# Altium schematic sheet H-9220 Backplane Board - DUT Bd1-2 (REV07).SchDoc
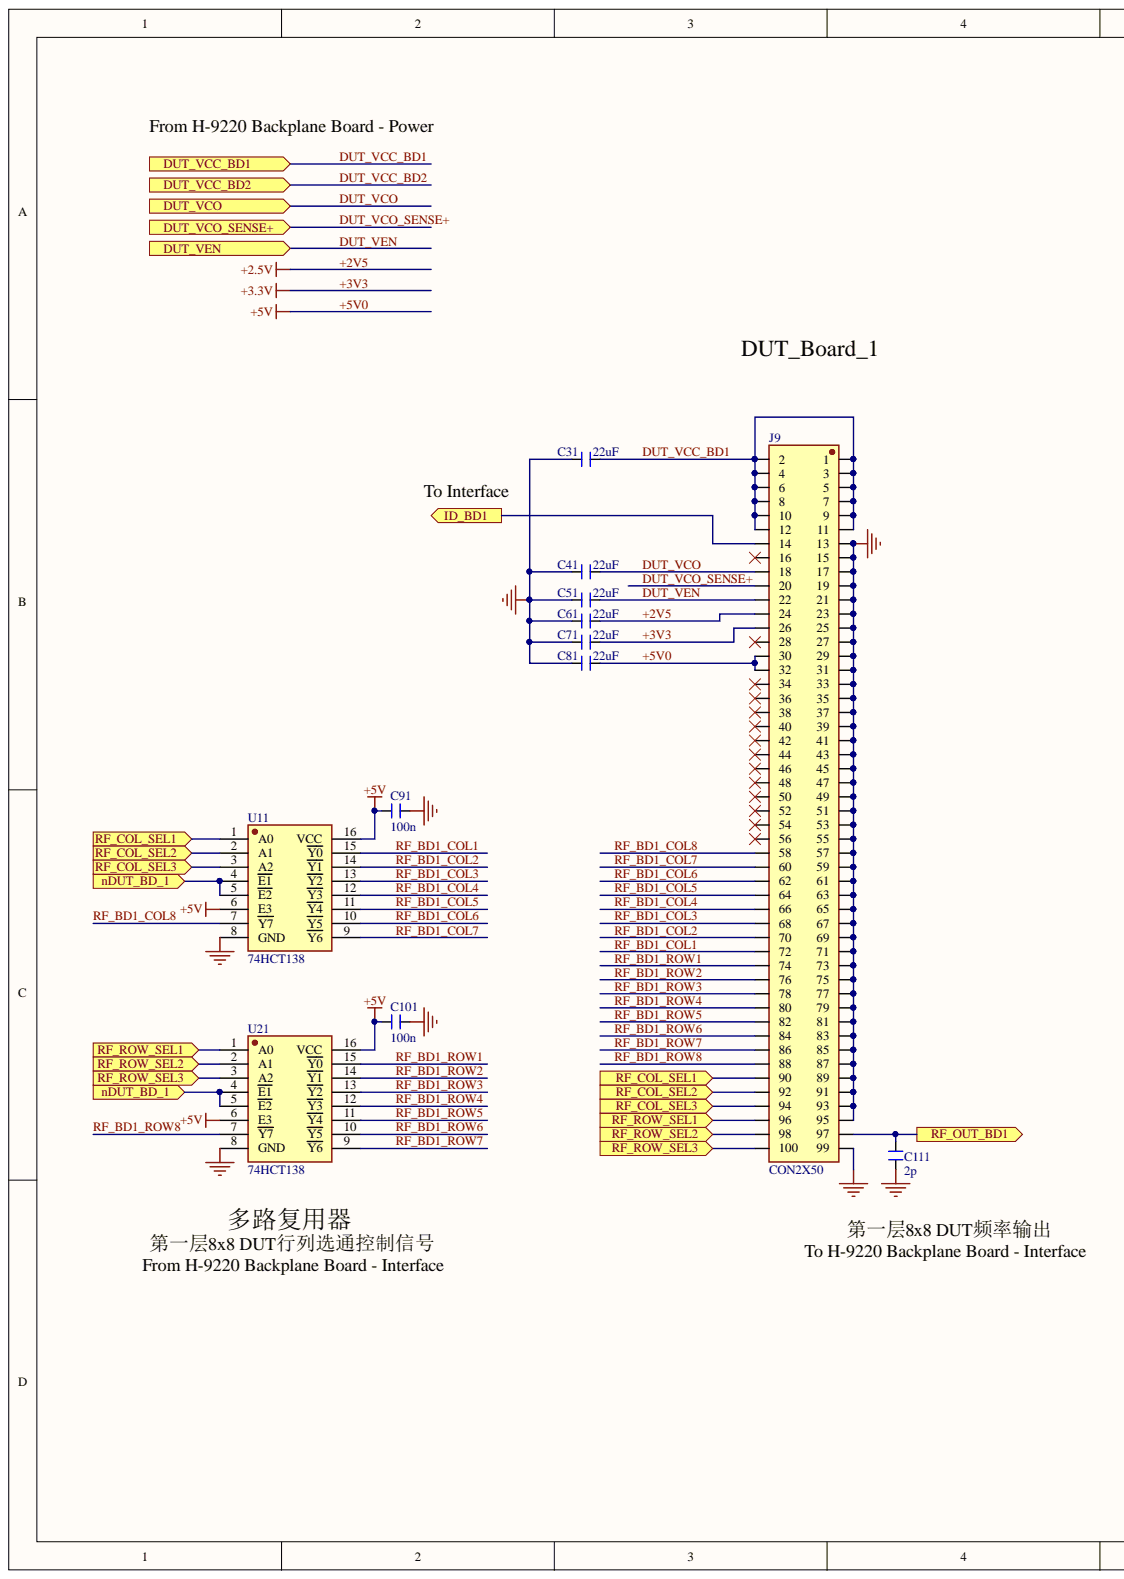
[diagram p0: H-9220 Backplane Board - DUT Bd1-2 (REV07).SchDoc, left]
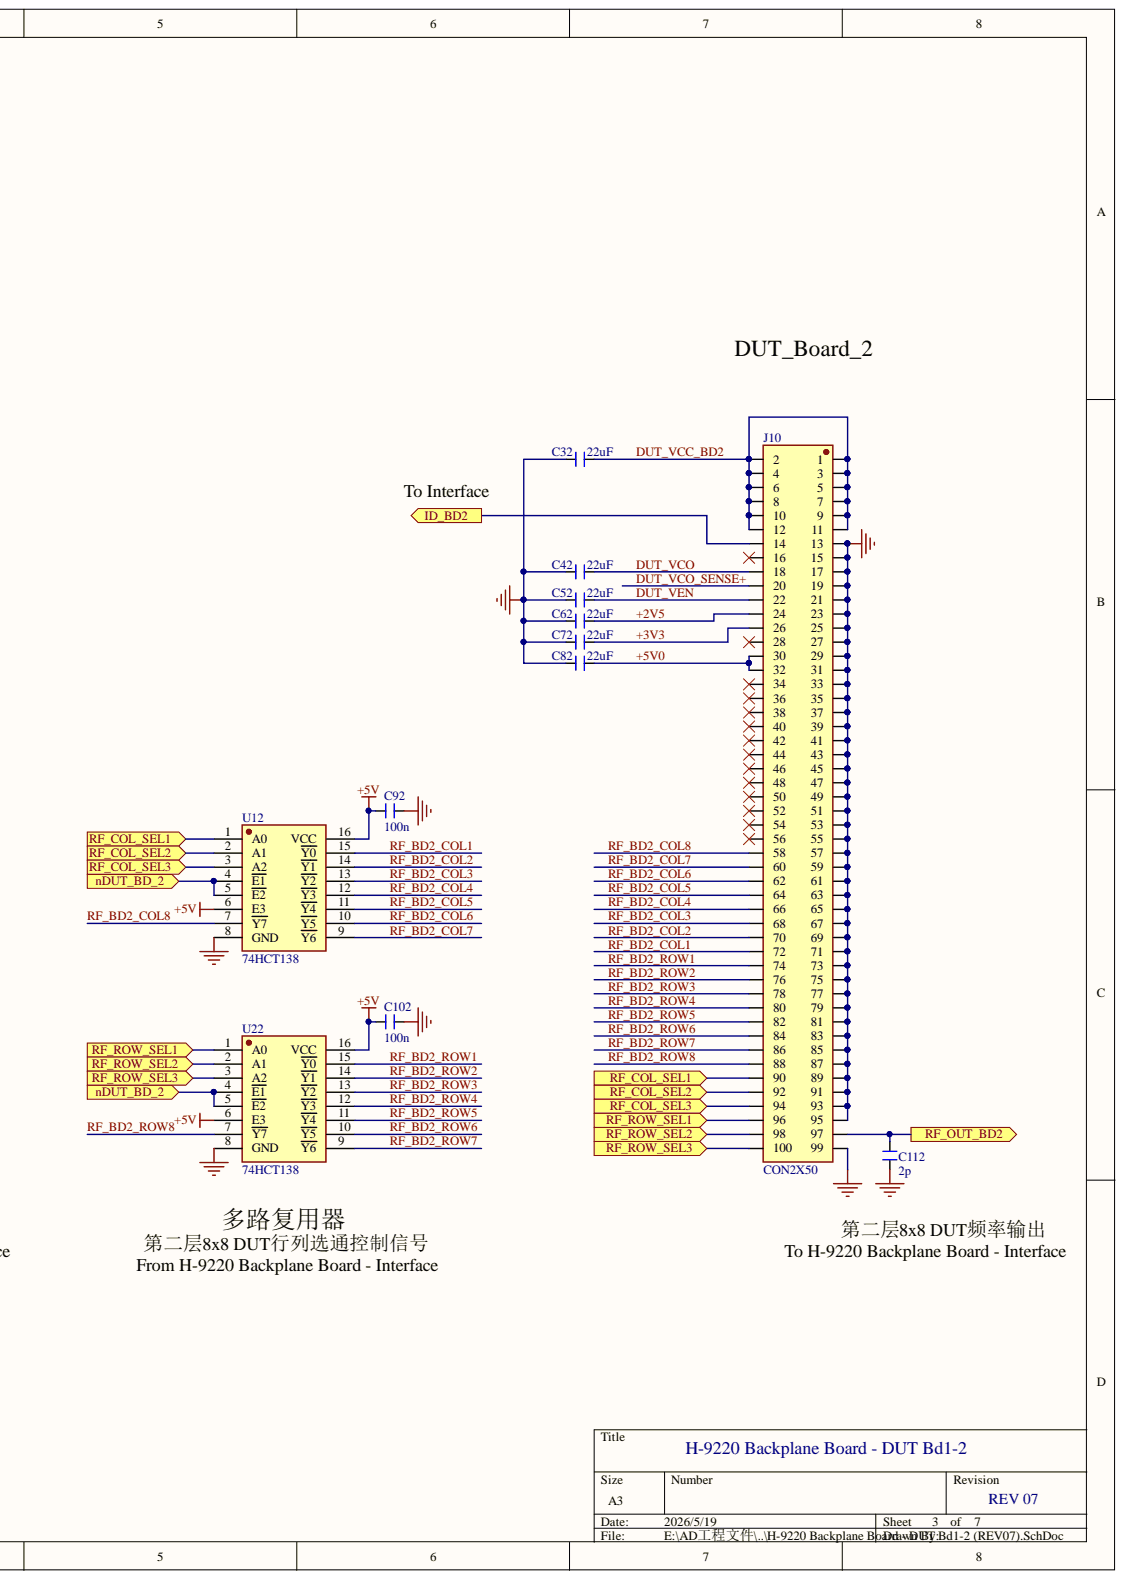
[diagram p1: H-9220 Backplane Board - DUT Bd1-2 (REV07).SchDoc, right]

## Parts
C31  22uF  [C-1206]
C32  22uF  [C-1206]
C41  22uF  [C-1206]
C42  22uF  [C-1206]
C51  22uF  [C-1206]
C52  22uF  [C-1206]
C61  22uF  [C-1206]
C62  22uF  [C-1206]
C71  22uF  [C-1206]
C72  22uF  [C-1206]
C81  22uF  [C-1206]
C82  22uF  [C-1206]
C91  100n  [C-0603]
C92  100n  [C-0603]
C101  100n  [C-0603]
C102  100n  [C-0603]
C111  2p  [C-0603]
C112  2p  [C-0603]
J9  CON2X50  [SFML-150-01-S-D-LC]
J10  CON2X50  [SFML-150-01-S-D-LC]
U11  74HCT138  [SOIC-16 (BODY=3.9MM)]
U12  74HCT138  [SOIC-16 (BODY=3.9MM)]
U21  74HCT138  [SOIC-16 (BODY=3.9MM)]
U22  74HCT138  [SOIC-16 (BODY=3.9MM)]

## Ports
DUT_VCC_BD1, DUT_VCC_BD2, DUT_VCO, DUT_VCO_SENSE+, DUT_VEN, ID_BD1, ID_BD2, nDUT_BD_1, nDUT_BD_2, RF_COL_SEL1, RF_COL_SEL2, RF_COL_SEL3, RF_OUT_BD1, RF_OUT_BD2, RF_ROW_SEL1, RF_ROW_SEL2, RF_ROW_SEL3

## Nets
GND: C31.2 C32.2 C41.2 C42.2 C51.2 C52.2 C61.2 C62.2 C71.2 C72.2 C81.2 C82.2 C91.2 C92.2 C101.2 C102.2 C111.2 C112.2 J9.13 J9.15 J9.17 J9.19 J9.21 J9.23 J9.25 J9.27 J9.29 J9.31 J9.33 J9.35 J9.37 J9.39 J9.41 J9.43 J9.45 J9.47 J9.49 J9.51 J9.53 J9.55 J9.57 J9.59 J9.61 J9.63 J9.65 J9.67 J9.69 J9.71 J9.73 J9.75 J9.77 J9.79 J9.81 J9.83 J9.85 J9.87 J9.89 J9.91 J9.93 J9.95 J9.99 J10.13 J10.15 J10.17 J10.19 J10.21 J10.23 J10.25 J10.27 J10.29 J10.31 J10.33 J10.35 J10.37 J10.39 J10.41 J10.43 J10.45 J10.47 J10.49 J10.51 J10.53 J10.55 J10.57 J10.59 J10.61 J10.63 J10.65 J10.67 J10.69 J10.71 J10.73 J10.75 J10.77 J10.79 J10.81 J10.83 J10.85 J10.87 J10.89 J10.91 J10.93 J10.95 J10.99 U11.8(GND) U12.8(GND) U21.8(GND) U22.8(GND)
+2V5: C61.1 C62.1 J9.24 J10.24
+3V3: C71.1 C72.1 J9.26 J10.26
+5V0: C81.1 C82.1 C91.1 C92.1 C101.1 C102.1 J9.30 J9.32 J10.30 J10.32 U11.6(E3) U11.16(VCC) U12.6(E3) U12.16(VCC) U21.6(E3) U21.16(VCC) U22.6(E3) U22.16(VCC)
DUT_VCC_BD1: C31.1 J9.1 J9.2 J9.3 J9.4 J9.5 J9.6 J9.7 J9.8 J9.9 J9.10 J9.11 J9.12 ; port DUT_VCC_BD1
DUT_VCC_BD2: C32.1 J10.1 J10.2 J10.3 J10.4 J10.5 J10.6 J10.7 J10.8 J10.9 J10.10 J10.11 J10.12 ; port DUT_VCC_BD2
DUT_VCO: C41.1 C42.1 J9.18 J10.18 ; port DUT_VCO
DUT_VCO_SENSE+: J9.20 J10.20 ; port DUT_VCO_SENSE+
DUT_VEN: C51.1 C52.1 J9.22 J10.22 ; port DUT_VEN
ID_BD1: J9.14 ; port ID_BD1
ID_BD2: J10.14 ; port ID_BD2
nDUT_BD_1: U11.4(E1) U11.5(E2) U21.4(E1) U21.5(E2) ; port nDUT_BD_1
nDUT_BD_2: U12.4(E1) U12.5(E2) U22.4(E1) U22.5(E2) ; port nDUT_BD_2
RF_BD1_COL1: J9.72 U11.15(Y0)
RF_BD1_COL2: J9.70 U11.14(Y1)
RF_BD1_COL3: J9.68 U11.13(Y2)
RF_BD1_COL4: J9.66 U11.12(Y3)
RF_BD1_COL5: J9.64 U11.11(Y4)
RF_BD1_COL6: J9.62 U11.10(Y5)
RF_BD1_COL7: J9.60 U11.9(Y6)
RF_BD1_COL8: J9.58 U11.7(Y7)
RF_BD1_ROW1: J9.74 U21.15(Y0)
RF_BD1_ROW2: J9.76 U21.14(Y1)
RF_BD1_ROW3: J9.78 U21.13(Y2)
RF_BD1_ROW4: J9.80 U21.12(Y3)
RF_BD1_ROW5: J9.82 U21.11(Y4)
RF_BD1_ROW6: J9.84 U21.10(Y5)
RF_BD1_ROW7: J9.86 U21.9(Y6)
RF_BD1_ROW8: J9.88 U21.7(Y7)
RF_BD2_COL1: J10.72 U12.15(Y0)
RF_BD2_COL2: J10.70 U12.14(Y1)
RF_BD2_COL3: J10.68 U12.13(Y2)
RF_BD2_COL4: J10.66 U12.12(Y3)
RF_BD2_COL5: J10.64 U12.11(Y4)
RF_BD2_COL6: J10.62 U12.10(Y5)
RF_BD2_COL7: J10.60 U12.9(Y6)
RF_BD2_COL8: J10.58 U12.7(Y7)
RF_BD2_ROW1: J10.74 U22.15(Y0)
RF_BD2_ROW2: J10.76 U22.14(Y1)
RF_BD2_ROW3: J10.78 U22.13(Y2)
RF_BD2_ROW4: J10.80 U22.12(Y3)
RF_BD2_ROW5: J10.82 U22.11(Y4)
RF_BD2_ROW6: J10.84 U22.10(Y5)
RF_BD2_ROW7: J10.86 U22.9(Y6)
RF_BD2_ROW8: J10.88 U22.7(Y7)
RF_COL_SEL1: J9.90 J10.90 U11.1(A0) U12.1(A0) ; port RF_COL_SEL1
RF_COL_SEL2: J9.92 J10.92 U11.2(A1) U12.2(A1) ; port RF_COL_SEL2
RF_COL_SEL3: J9.94 J10.94 U11.3(A2) U12.3(A2) ; port RF_COL_SEL3
RF_OUT_BD1: C111.1 J9.97 ; port RF_OUT_BD1
RF_OUT_BD2: C112.1 J10.97 ; port RF_OUT_BD2
RF_ROW_SEL1: J9.96 J10.96 U21.1(A0) U22.1(A0) ; port RF_ROW_SEL1
RF_ROW_SEL2: J9.98 J10.98 U21.2(A1) U22.2(A1) ; port RF_ROW_SEL2
RF_ROW_SEL3: J9.100 J10.100 U21.3(A2) U22.3(A2) ; port RF_ROW_SEL3

Not connected: J9 16 28 34 36 38 40 42 44 46 48 50 52 54 56; J10 16 28 34 36 38 40 42 44 46 48 50 52 54 56

## Library data of the parts
C31 C32 C41 C42 C51 C52 C61 C62 C71 C72 C81 C82 C91 C92 C101 C102 C111 C112 = CAP: Component Kind: Standard; Library Name: HW SCH Lib.SCHLIB
J9 J10 = CON-2x50P: Component Kind: Standard; Library Name: HW SCH Lib.SCHLIB
U11 U12 U21 U22 = 74HCT138: Component Kind: Standard; Library Name: HW SCH Lib.SCHLIB
